# Altium schematic sheet Sheet3.SchDoc
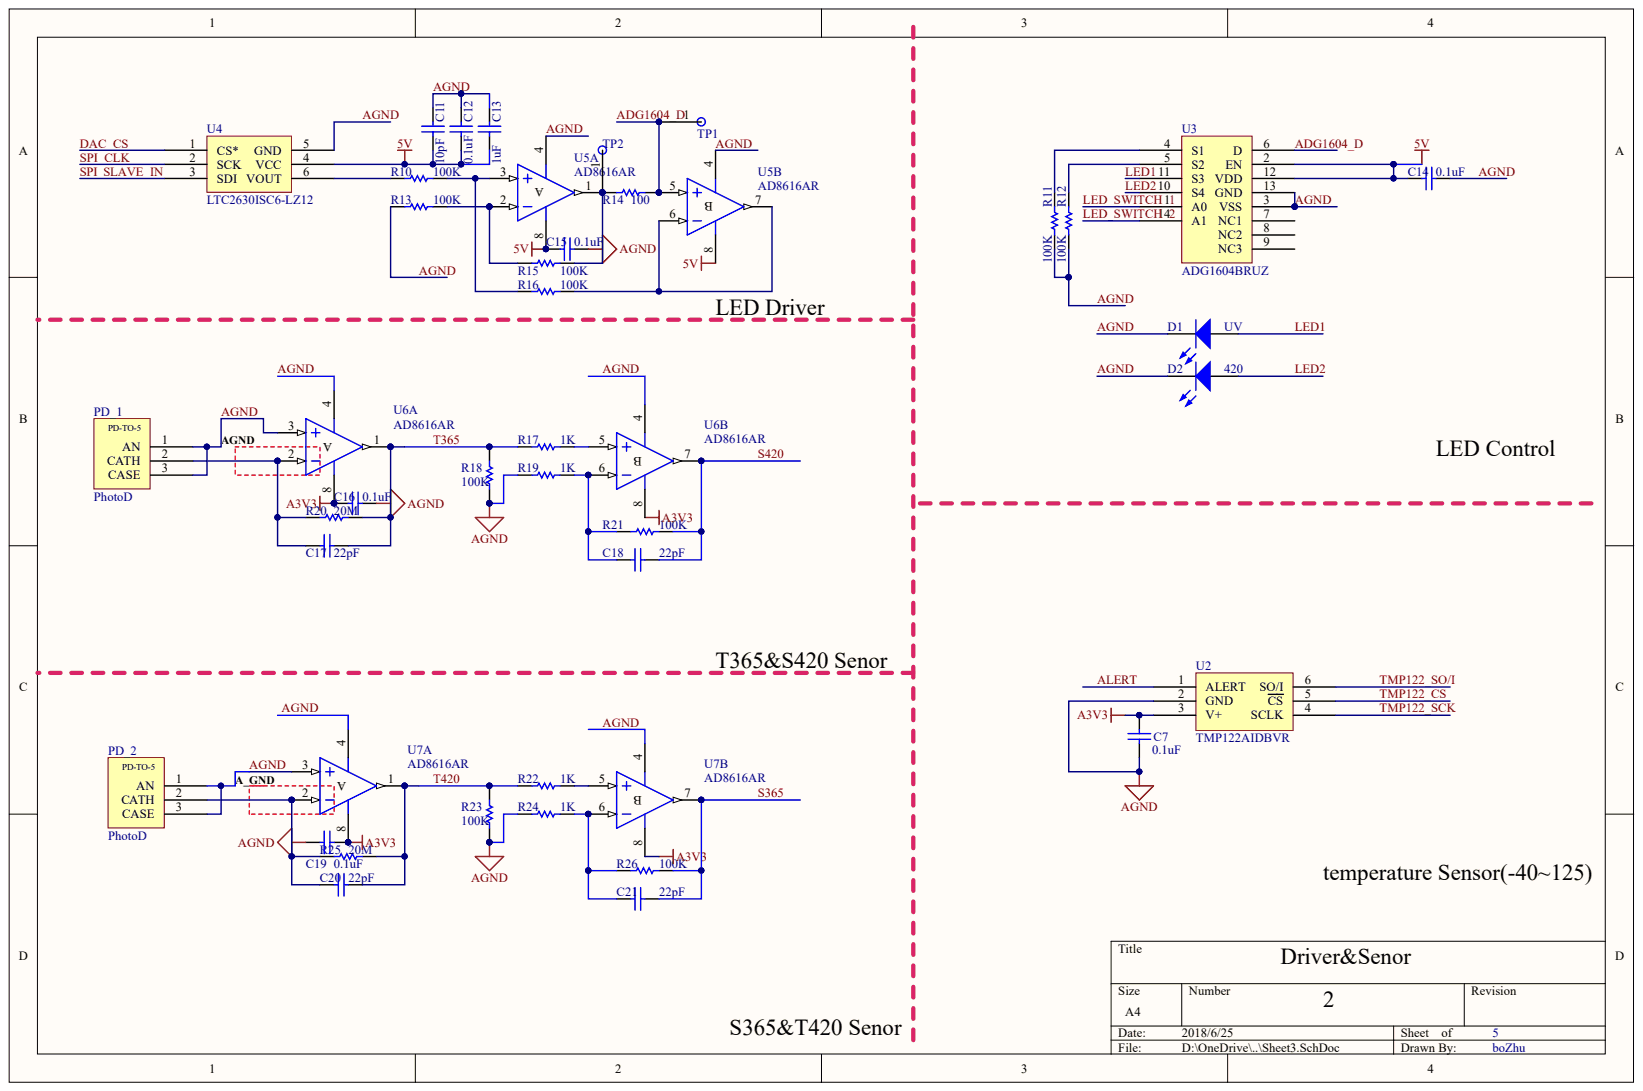
[diagram p0: Sheet3.SchDoc]

## Parts
C7  value 0.1uF  (hidden comment: Cap)
C11  value 10pF  (hidden comment: Cap)
C12  value 0.1uF  (hidden comment: Cap)
C13  value 1uF  (hidden comment: Cap)
C14  value 0.1uF  (hidden comment: Cap)
C15  value 0.1uF  (hidden comment: Cap)
C16  value 0.1uF  (hidden comment: Cap)
C17  value 22pF  (hidden comment: Cap)
C18  value 22pF  (hidden comment: Cap)
C19  value 0.1uF  (hidden comment: Cap)
C20  value 22pF  (hidden comment: Cap)
C21  value 22pF  (hidden comment: Cap)
D1  UV
D2  420
PD_1  PhotoD
PD_2  PhotoD
R10  value 100K  (hidden comment: Res1)
R11  value 100K  (hidden comment: Res1)
R12  value 100K  (hidden comment: Res1)
R13  value 100K  (hidden comment: Res1)
R14  value 100  (hidden comment: Res1)
R15  value 100K  (hidden comment: Res1)
R16  value 100K  (hidden comment: Res1)
R17  value 1K  (hidden comment: Res1)
R18  value 100K  (hidden comment: Res1)
R19  value 1K  (hidden comment: Res1)
R20  value 20M  (hidden comment: Res1)
R21  value 100K  (hidden comment: Res1)
R22  value 1K  (hidden comment: Res1)
R23  value 100K  (hidden comment: Res1)
R24  value 1K  (hidden comment: Res1)
R25  value 20M  (hidden comment: Res1)
R26  value 100K  (hidden comment: Res1)
TP1  Test Point  (value not drawn)
TP2  Test Point  (value not drawn)
U2  TMP122AIDBVR
U3  ADG1604BRUZ
U4  LTC2630ISC6-LZ12
U5  AD8616AR  (parts A,B)
U6  AD8616AR  (parts A,B)
U7  AD8616AR  (parts A,B)

## Nets
5V: C11.2 C12.2 C13.2 C14.2 C15.2 U3.2(EN) U3.12(VDD) U4.4(VCC) U5.8
A3V3: C7.2 C16.1 C19.2 U2.3(V+) U6.8 U7.8
ADG1604_D: R14.1 TP1.1 U3.6(D) U5.5
AGND: C7.1 C11.1 C12.1 C13.1 C14.1 C15.1 C16.2 C19.1 D1.2 D2.2 PD_1.1 PD_1.3 PD_2.1 PD_2.3 R11.1 R12.1 R13.2 R18.2 R19.2 R23.2 R24.2 U2.2(GND) U3.3(VSS) U3.13(GND) U4.5(GND) U5.4 U6.3 U6.4 U7.3 U7.4
ALERT: U2.1(ALERT)
DAC_CS: U4.1(CS*)
LED1: D1.1 U3.11(S3)
LED2: D2.1 U3.10(S4)
LED_SWITCH_1: U3.1(A0)
LED_SWITCH_2: U3.14(A1)
S365: C21.1 R26.1 U7.7
S420: C18.1 R21.1 U6.7
SPI_CLK: U4.2(SCK)
SPI_SLAVE_IN: U4.3(SDI)
T365: C17.1 R17.2 R18.1 R20.1 U6.1
T420: C20.1 R22.2 R23.1 R25.1 U7.1
TMP122_CS: U2.5(CS)
TMP122_SCK: U2.4(SCLK)
TMP122_SO/I: U2.6(SO/I)
NetC17_2: C17.2 PD_1.2 R20.2 U6.2
NetC18_2: C18.2 R19.1 R21.2 U6.6
NetC20_2: C20.2 PD_2.2 R25.2 U7.2
NetC21_2: C21.2 R24.1 R26.2 U7.6
NetR10_1: R10.1 R16.2 U5.3
NetR10_2: R10.2 U4.6(VOUT)
NetR11_2: R11.2 U3.4(S1)
NetR12_2: R12.2 U3.5(S2)
NetR13_1: R13.1 R15.2 U5.2
NetR14_2: R14.2 R15.1 TP2.1 U5.1
NetR16_1: R16.1 U5.6 U5.7
NetR17_1: R17.1 U6.5
NetR22_1: R22.1 U7.5

Not connected: U3 7(NC1) 8(NC2) 9(NC3)

## Library data of the parts
C7 C11-C21 D1 D2 PD_1 PD_2 R10-R26 TP1 TP2 U2-U7 = (no symbol name)
  C7 C11-C21: Published: 8-Jun-2000; LatestRevisionDate: 17-Jul-2002; LatestRevisionNote: Re-released for DXP Platform.; PackageReference: RAD-0.3
  D1 D2: Published: 8-Jun-2000; LatestRevisionDate: 08-Jul-2005; LatestRevisionNote: Mech Layer 1 removed.; PackageReference: LED-0
  R10-R26: Published: 8-Jun-2000; LatestRevisionDate: 17-Jul-2002; LatestRevisionNote: Re-released for DXP Platform.; PackageReference: AXIAL-0.3
  U5-U7: Published: 8-Jun-2000; LatestRevisionDate: 13-Apr-2006; LatestRevisionNote: IPC-7351 Footprint Added.; DatasheetVersion: REV. B 1998; PackageVersion: revA; PackageReference: SO-8; PackageDescription: 8-Pin Small Outline Integrated Circuit 1.27 mm Pitch; Code_JEDEC: MS-012-AA; Code_IPC: SOIC127P600-8; ComponentLink1Description: Manufacturer Link; ComponentLink1URL: http://www.analog.com/en/prod/0,,759_786_AD812%2C00.html; ComponentLink2Description: Datasheet; ComponentLink2URL: http://www.analog.com/UploadedFiles/Data_Sheets/200001408AD812_b.pdf
  C7 C11-C21 D1 D2 R10-R26 U5-U7: Publisher: Altium Limited
